# Altium schematic sheet Sensor Interface.SchDoc(Designator)
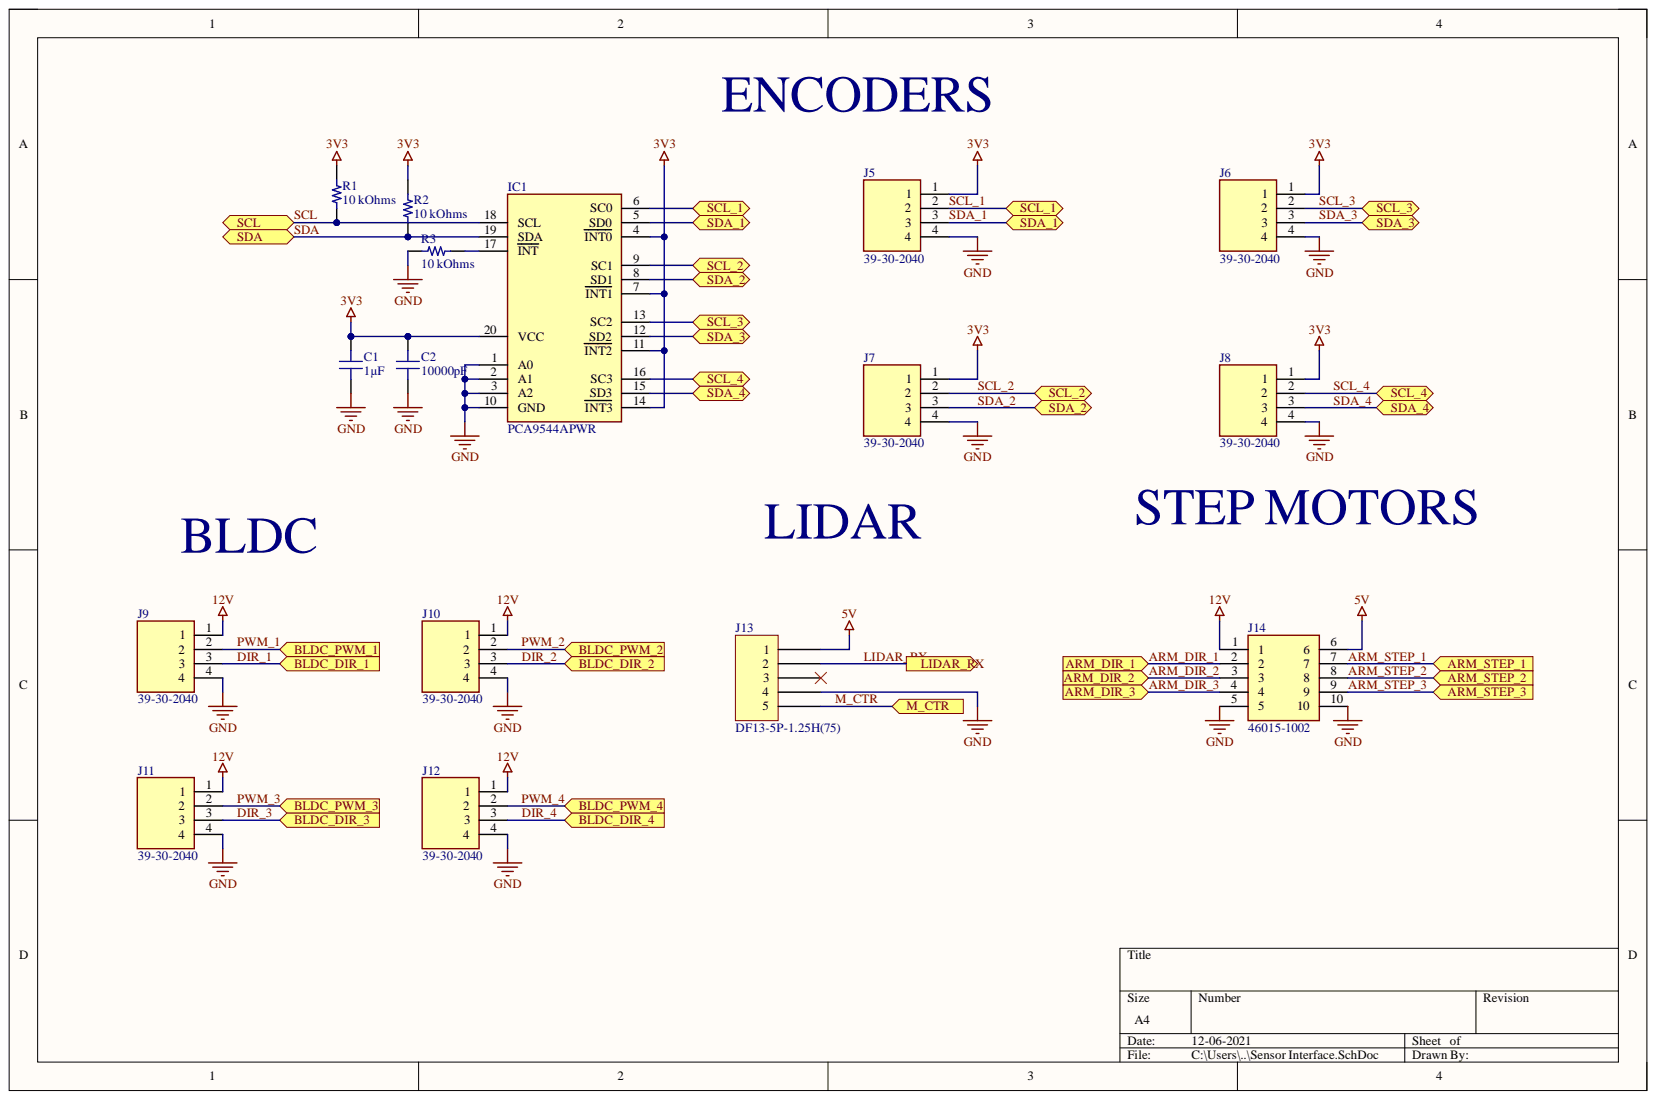
[diagram p0: Sensor Interface.SchDoc]

## Parts
C1  1µF  [CAP 0603_1608]
C2  10000pF  [CAP 0603_1608]
IC1  PCA9544APWR  [SOP65P640X120-20N]
J5  39-30-2040  [39302040]
J6  39-30-2040  [39302040]
J7  39-30-2040  [39302040]
J8  39-30-2040  [39302040]
J9  39-30-2040  [39302040]
J10  39-30-2040  [39302040]
J11  39-30-2040  [39302040]
J12  39-30-2040  [39302040]
J13  DF13-5P-1.25H(75)  [HIROSE DF13-5P-1.25H]
J14  46015-1002  [460151002]
R1  10 kOhms  [RES 0603_1608]
R2  10 kOhms  [RES 0603_1608]
R3  10 kOhms  [RES 0603_1608]

## Ports
ARM_DIR_1, ARM_DIR_2, ARM_DIR_3, ARM_STEP_1, ARM_STEP_2, ARM_STEP_3, BLDC_DIR_1, BLDC_DIR_2, BLDC_DIR_3, BLDC_DIR_4, BLDC_PWM_1, BLDC_PWM_2, BLDC_PWM_3, BLDC_PWM_4, LIDAR_RX, M_CTR, SCL, SCL_1, SCL_2, SCL_3, SCL_4, SDA, SDA_1, SDA_2, SDA_3, SDA_4

## Nets
3V3: C1.2 C2.2 IC1.4(INT0) IC1.7(INT1) IC1.11(INT2) IC1.14(INT3) IC1.20(VCC) J5.1 J6.1 J7.1 J8.1 R1.2 R2.2
5V: J13.1 J14.6
12V: J9.1 J10.1 J11.1 J12.1 J14.1
GND: C1.1 C2.1 IC1.1(A0) IC1.2(A1) IC1.3(A2) IC1.10(GND) J5.4 J6.4 J7.4 J8.4 J9.4 J10.4 J11.4 J12.4 J13.4 J14.5 J14.10 R3.1
ARM_DIR_1: J14.2 ; port ARM_DIR_1
ARM_DIR_2: J14.3 ; port ARM_DIR_2
ARM_DIR_3: J14.4 ; port ARM_DIR_3
ARM_STEP_1: J14.7 ; port ARM_STEP_1
ARM_STEP_2: J14.8 ; port ARM_STEP_2
ARM_STEP_3: J14.9 ; port ARM_STEP_3
DIR_1: J9.3 ; port BLDC_DIR_1
DIR_2: J10.3 ; port BLDC_DIR_2
DIR_3: J11.3 ; port BLDC_DIR_3
DIR_4: J12.3 ; port BLDC_DIR_4
LIDAR_RX: J13.2 ; port LIDAR_RX
M_CTR: J13.5 ; port M_CTR
PWM_1: J9.2 ; port BLDC_PWM_1
PWM_2: J10.2 ; port BLDC_PWM_2
PWM_3: J11.2 ; port BLDC_PWM_3
PWM_4: J12.2 ; port BLDC_PWM_4
SCL: IC1.18(SCL) R1.1 ; port SCL
SCL_1: IC1.6(SC0) J5.2 ; port SCL_1
SCL_2: IC1.9(SC1) J7.2 ; port SCL_2
SCL_3: IC1.13(SC2) J6.2 ; port SCL_3
SCL_4: IC1.16(SC3) J8.2 ; port SCL_4
SDA: IC1.19(SDA) R2.1 ; port SDA
SDA_1: IC1.5(SD0) J5.3 ; port SDA_1
SDA_2: IC1.8(SD1) J7.3 ; port SDA_2
SDA_3: IC1.12(SD2) J6.3 ; port SDA_3
SDA_4: IC1.15(SD3) J8.3 ; port SDA_4
NetIC1_17: IC1.17(INT) R3.2

Not connected: J13 3

## Library data of the parts
C1 = C0603C105K4RACAUTO: CAP CER 0603 1UF 16V X7R 10%; Manufacturer: KEMET; Database Table Name: Capacitors - Ceramic - 0603; Applications: Automotive, Bypass, Decoupling; Capacitance: 1µF; Component Kind: Standard; ComponentLink1Description: Datasheet; ComponentLink1URL: https://api.kemet.com/component-edge/download/datasheet/C0603C105K4RACAUTO.pdf; ComponentLink2Description: DigiKey Link; ComponentLink2URL: http://digikey.com/product-detail/en/kemet/C0603C105K4RACAUTO/399-17704-1-ND/8635855; Device Package: 0603 (1608 Metric); LastUpdated: 2020-09-02 1:03:36 AM; Library Name: Celestial Altium Library - altium_library.DbLib; Lifecycle Status: Active; Minimum Order: 1; Mounting Type: Surface Mount, MLCC; Operating Temperature: -55°C ~ 125°C; Package / Case: 0603 (1608 Metric); Packaging: TapeAndReel; Part Number: C0603C105K4RACAUTO; Part Status: Active; PartId: 2179; Price: 0.02; Ratings: AEC-Q200; Series: SMD Auto X7R; Size / Dimension: 0.063" L x 0.031" W (1.60mm x 0.80mm); Supplier 1: DigiKey; Supplier Part Number 1: 399-17704-1-ND; Temperature Coefficient: X7R; Thickness (Max): 0.037" (0.95mm); Tolerance: ±10%; Value: 1µF; Voltage - Rated: 16V
C2 = C0603C103M4RACAUTO: CAP CER 0603 .01UF 16V X7R 20% A; Manufacturer: KEMET; Database Table Name: Capacitors - Ceramic - 0603; Applications: Automotive, Bypass, Decoupling; Capacitance: 10000pF; Component Kind: Standard; ComponentLink1Description: Datasheet; ComponentLink1URL: https://api.kemet.com/component-edge/download/datasheet/C0603C103M4RACAUTO.pdf; ComponentLink2Description: DigiKey Link; ComponentLink2URL: http://digikey.com/product-detail/en/kemet/C0603C103M4RACAUTO/399-13714-1-ND/6219642; Device Package: 0603 (1608 Metric); LastUpdated: 2020-09-02 1:03:33 AM; Library Name: Celestial Altium Library - altium_library.DbLib; Lifecycle Status: Active; Minimum Order: 1; Mounting Type: Surface Mount, MLCC; Operating Temperature: -55°C ~ 125°C; Package / Case: 0603 (1608 Metric); Packaging: TapeAndReel; Part Number: C0603C103M4RACAUTO; Part Status: Active; PartId: 3731; Price: 0.02; Ratings: AEC-Q200; Series: SMD Auto X7R; Size / Dimension: 0.063" L x 0.031" W (1.60mm x 0.80mm); Supplier 1: DigiKey; Supplier Part Number 1: 399-13714-1-ND; Temperature Coefficient: X7R; Thickness (Max): 0.034" (0.87mm); Tolerance: ±20%; Value: 10000pF; Voltage - Rated: 16V
IC1 = PCA9544APWR: Integrated Circuit; Manufacturer_Name: Texas Instruments; Arrow Part Number: PCA9544APWR; Arrow Price/Stock: https://www.arrow.com/en/products/pca9544apwr/texas-instruments; Component Kind: Standard; Datasheet Link: http://www.ti.com/lit/gpn/pca9544a; Height: 1.2mm; Library Name: SamacSys.SchLib; Manufacturer_Part_Number: PCA9544APWR; Mouser Part Number: 595-PCA9544APWR; Mouser Price/Stock: https://www.mouser.co.uk/ProductDetail/Texas-Instruments/PCA9544APWR?qs=wgAEGBTxy7mScfqW4P%2FhLg%3D%3D
J5-J12 = 39-30-2040: Connector; Manufacturer_Name: Molex; Component Kind: Standard; Datasheet Link: https://www.mouser.com/datasheet/2/276/0039302040_PCB_HEADERS-160099.pdf; Height: 13.18mm; Manufacturer_Part_Number: 39-30-2040; Mouser Part Number: 538-39-30-2040; Mouser Price/Stock: https://www.mouser.co.uk/ProductDetail/Molex/39-30-2040?qs=cm0cgiBciNb%252Bk5q295QaGg%3D%3D
  J9-J12: Library Name: SamacSys.SchLib
J13 = DF13-5P-1.25H(75): CONN HEADER SMD R/A 5POS 1.25MM; Manufacturer: Hirose Electric Co Ltd; Current Rating (Amps): 1A; Database Table Name: Connectors - Rectangular Headers Male Pins; Material Flammability Rating: UL94 V-0; Number of Positions: 5; Number of Positions Loaded: All; Pitch - Mating: 0.049" (1.25mm); Shrouding: Shrouded - 4 Wall; Style: Board to Cable/Wire; Voltage Rating: 150V; Component Kind: Standard; ComponentLink1Description: Datasheet; ComponentLink1URL: https://www.hirose.com/product/document?clcode=CL0536-0109-0-76&productname=DF13-10P-1.25DSA(76)&series=DF13&documenttype=Catalog&lang=en&documentid=D31687_en; ComponentLink2Description: DigiKey Link; ComponentLink2URL: //digikey.com/product-detail/en/hirose-electric-co-ltd/DF13-5P-1.25H-75/H126404-ND/9170639; Connector Type: Header; Contact Finish - Mating: Tin; Contact Finish - Post: Tin; Contact Finish Thickness - Mating: 39.4µin (1.00µm); Contact Material: Brass; Contact Shape: Square; Contact Type: Male Pin; Fastening Type: Detent Lock; Features: Board Guide, Solder Retention; Insulation Color: Beige; Insulation Height: 0.142" (3.60mm); Insulation Material: Polyamide (PA), Nylon; LastUpdated: 2019-12-16 7:23:39 PM; Library Name: Celestial Altium Library - altium_library.DbLib; Lifecycle Status: 0; Minimum Order: 1; Mounting Type: Surface Mount, Right Angle; Number of Rows: 1; Operating Temperature: -35°C ~ 85°C; Packaging: Tube; Part Number: DF13-5P-1.25H(75); Part Status: Active; PartId: 4; Price: 0.48; Series: DF13; Supplier 1: DigiKey; Supplier Part Number 1: H126404-ND; Termination: Solder
J14 = 46015-1002: Connector; Manufacturer_Name: Molex; Component Kind: Standard; Datasheet Link: https://www.molex.com/pdm_docs/sd/460151002_sd.pdf; Height: 13.3mm; Library Name: SamacSys.SchLib; Manufacturer_Part_Number: 46015-1002; Mouser Part Number: 538-46015-1002; Mouser Price/Stock: https://www.mouser.co.uk/ProductDetail/Molex/46015-1002?qs=vuaaJtqKbMZiZUhECIrnag%3D%3D
R1-R3 = AF0603FR-0710KL: RES SMD 10K OHM 1% 1/10W 0603; Manufacturer: Yageo; Database Table Name: Resistors - Surface Mount - 0603; Power (Watts): 0.1W, 1/10W; Temperature Coefficient: ±100ppm/°C; Component Kind: Standard; ComponentLink1Description: Datasheet; ComponentLink1URL: http://www.yageo.com/documents/recent/PYu-AF_51_RoHS_L_6.pdf; ComponentLink2Description: DigiKey Link; ComponentLink2URL: http://digikey.com/product-detail/en/yageo/AF0603FR-0710KL/311-10KLMCT-ND/2828170; Composition: Thick Film; Device Package: 0603 (1608 Metric); Features: Anti-Sulfur, Moisture Resistant; Height - Seated (Max): 0.022" (0.55mm); LastUpdated: 2020-09-02 1:10:01 AM; Library Name: Celestial Altium Library - altium_library.DbLib; Lifecycle Status: Active; Minimum Order: 1; Number of Terminations: 2; Operating Temperature: -55°C ~ 155°C; Package / Case: 0603 (1608 Metric); Packaging: TapeAndReel; Part Number: AF0603FR-0710KL; Part Status: Active; PartId: 16021; Price: 0.01; Resistance: 10 kOhms; Series: AF; Size / Dimension: 0.063" L x 0.031" W (1.60mm x 0.80mm); Supplier 1: DigiKey; Supplier Device Package: 0603; Supplier Part Number 1: 311-10KLMCT-ND; Tolerance: ±1%; Value: 10 kOhms
